# Altium schematic sheet CM001_V4_2.SchDoc(CM001_V4_2)
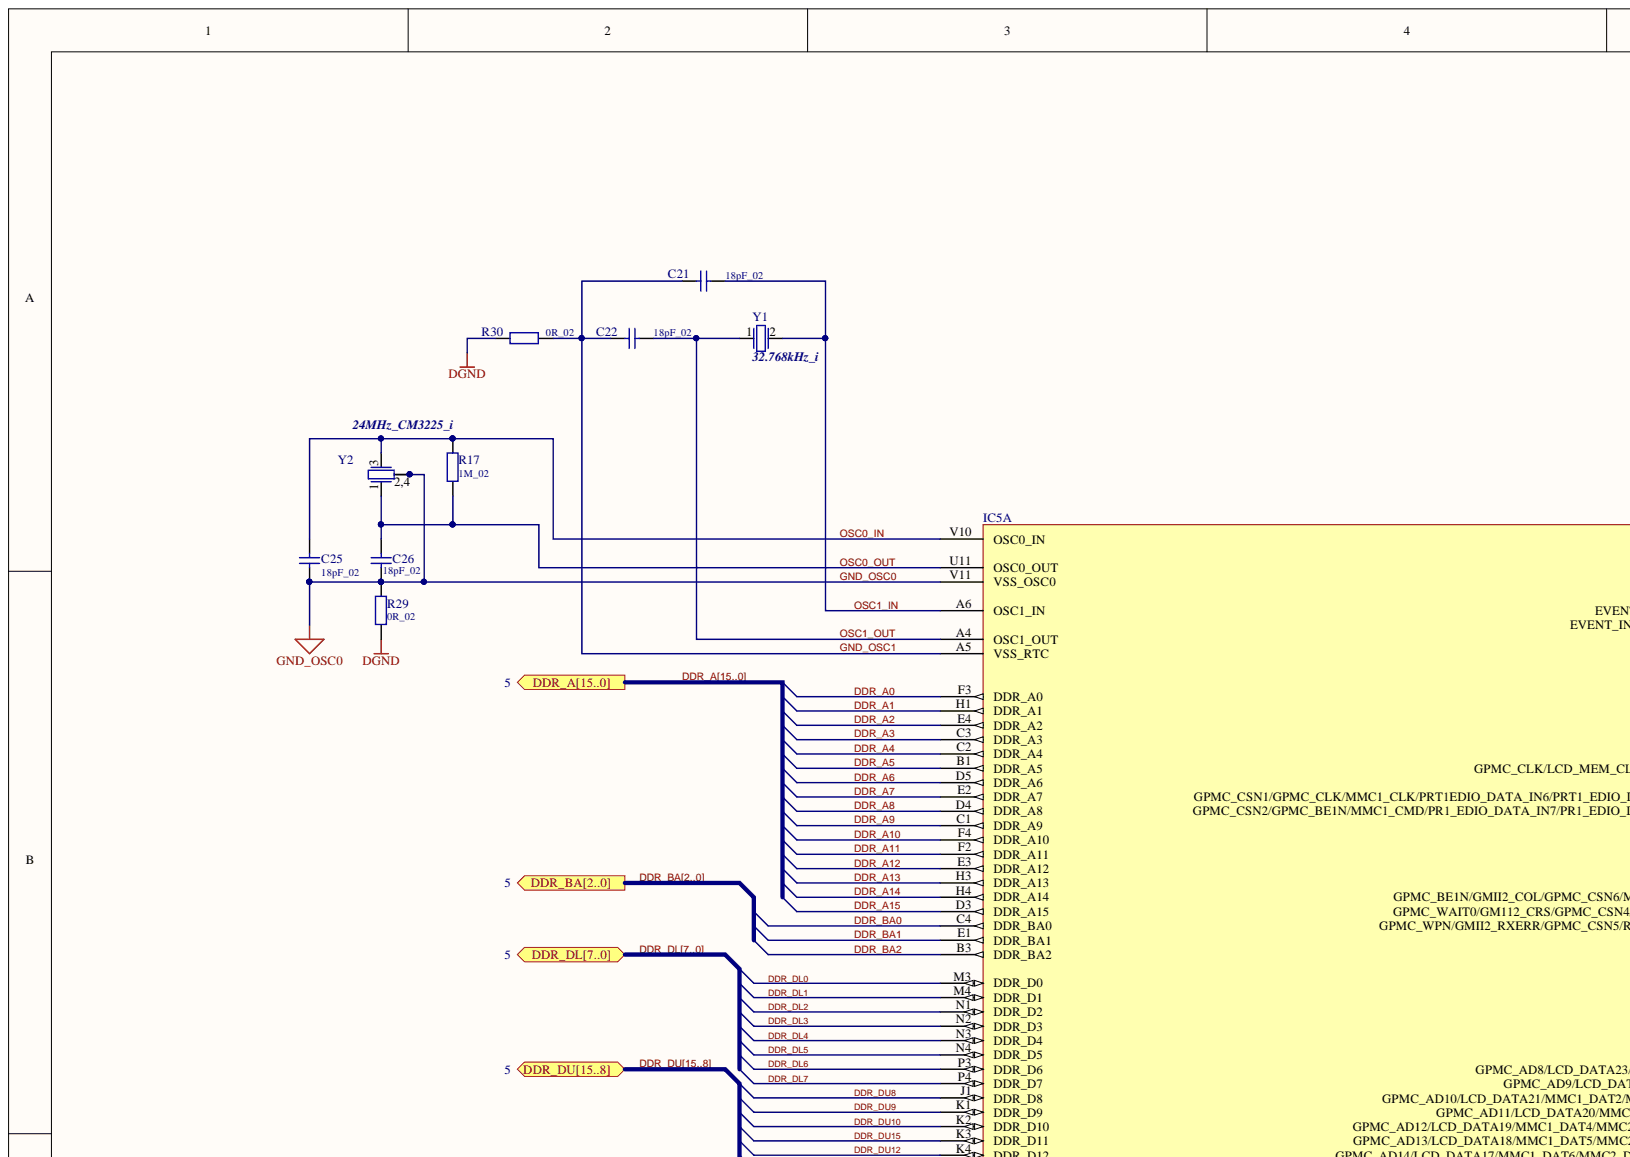
[diagram p0: CM001_V4_2.SchDoc, top left]
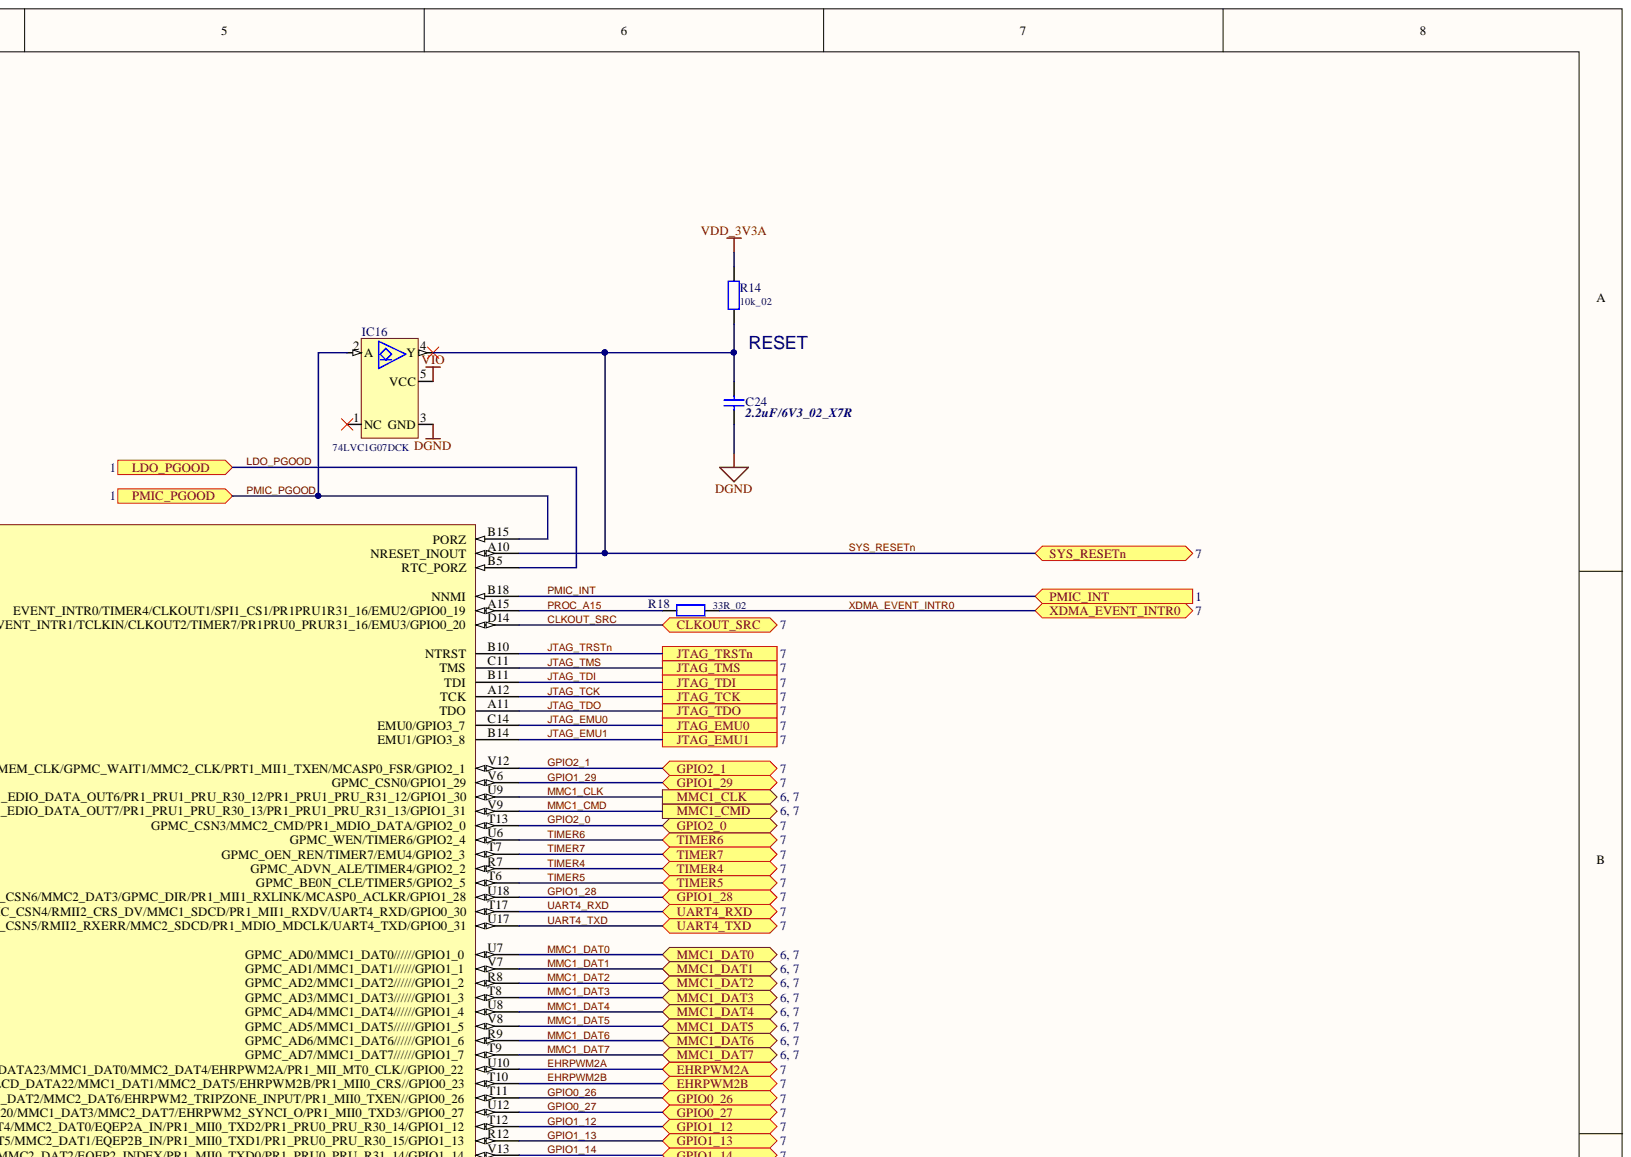
[diagram p1: CM001_V4_2.SchDoc, top right]
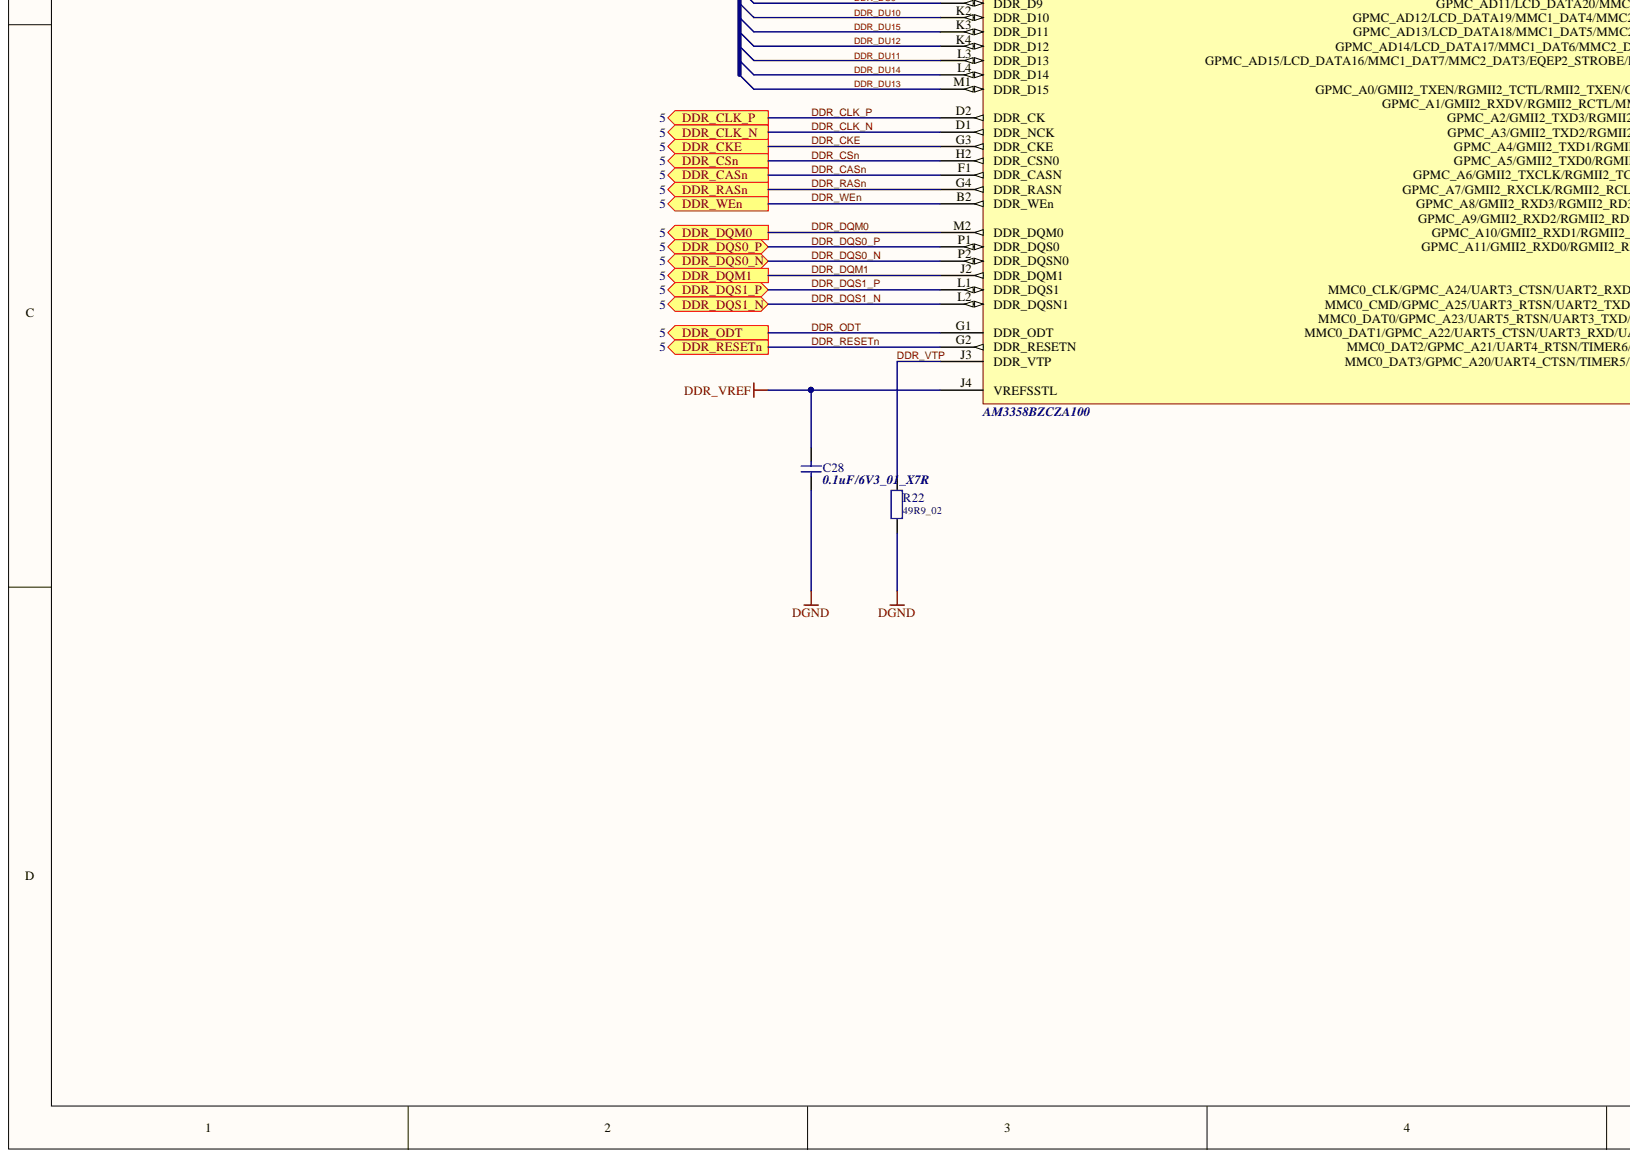
[diagram p2: CM001_V4_2.SchDoc, bottom left]
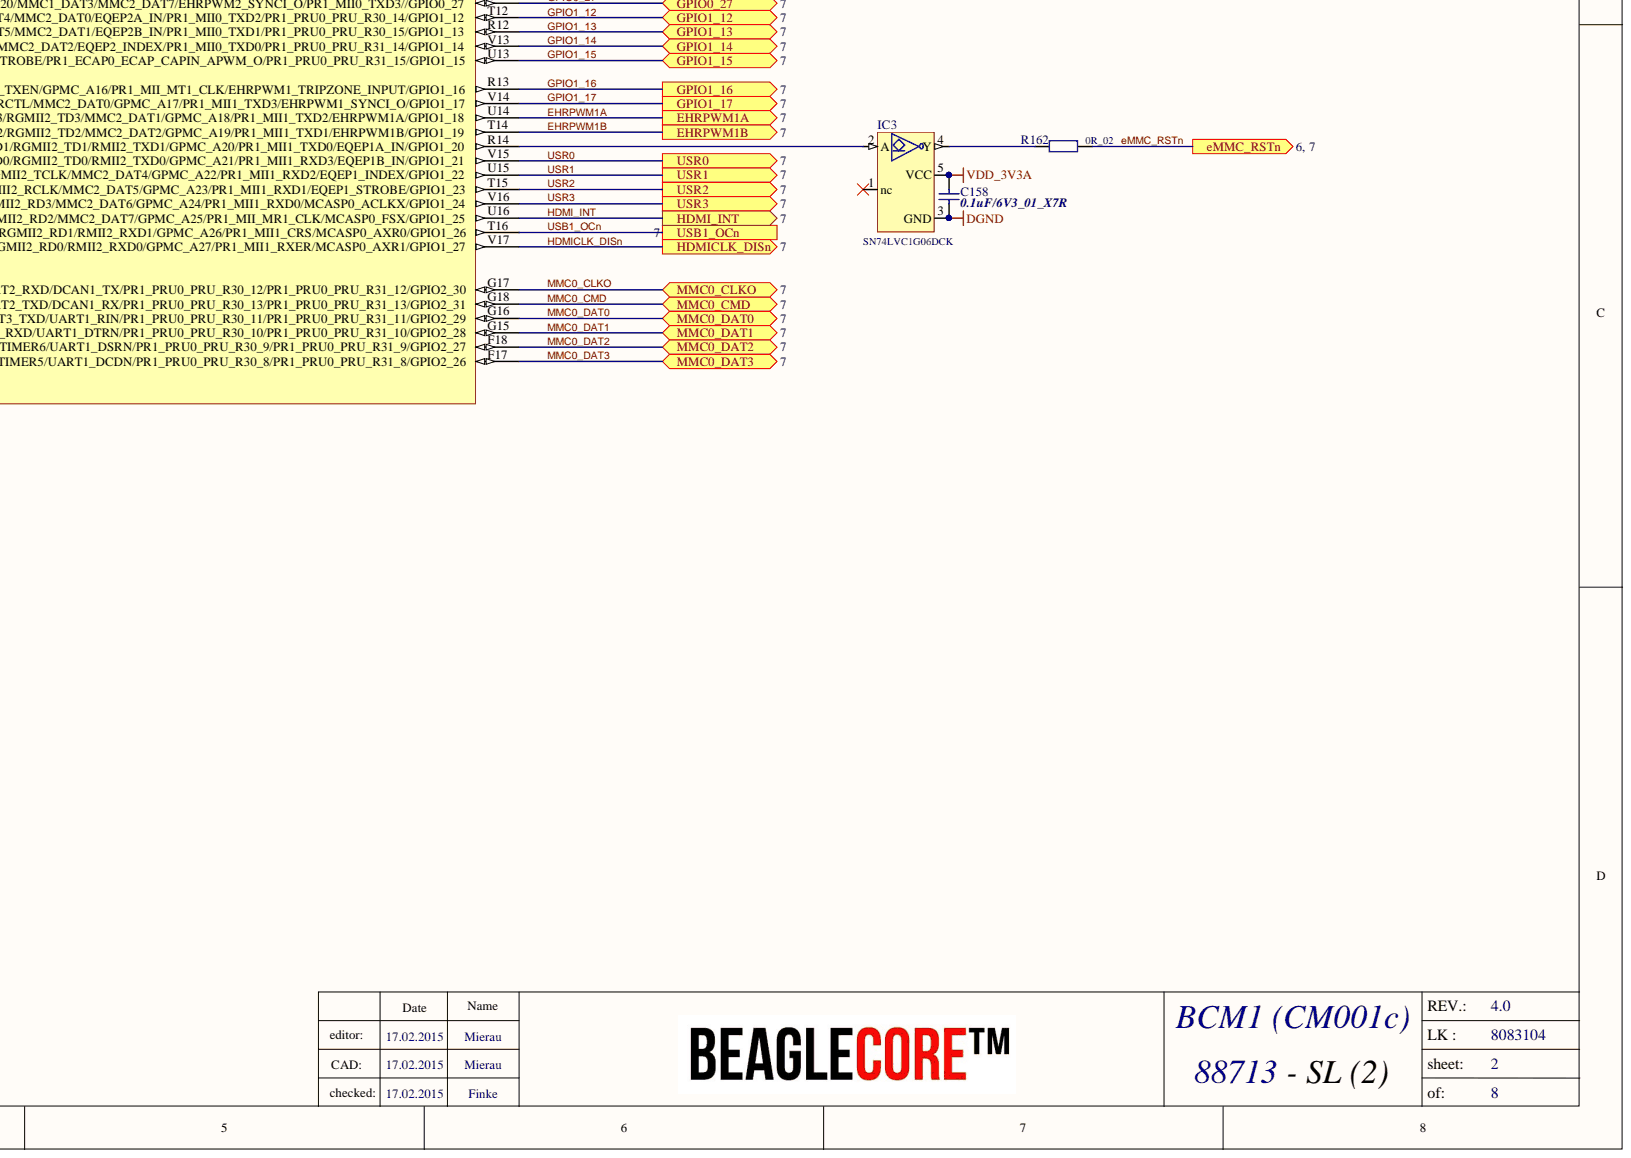
[diagram p3: CM001_V4_2.SchDoc, bottom right]

## Parts
C21  18pF_02  [CC1005-0402]
C22  18pF_02  [CC1005-0402]
C24  2.2uF/6V3_02_X7R  [CC1005-0402]
C24  2.2uF/6V3_02_X7R  [CC1005-0402]
C25  18pF_02  [CC1005-0402]
C26  18pF_02  [CC1005-0402]
C28  0.1uF/6V3_01_X7R  [CC0603-0201]
C28
C158  0.1uF/6V3_01_X7R  [CC0603-0201]
C158
IC3  SN74LVC1G06DCK  [SOT353]
IC5  AM3358BZCZ100  [BGA324_RM0.8_15x15]  (value not drawn)  (part A)
IC16  74LVC1G07DCK  [SOT353]
R14  10k_02  [CR1005-0402]
R17  1M_02  [CR1005-0402]
R18  33R_02  [CR1005-0402]
R22  49R9_02  [CR1005-0402]
R29  0R_02  [CR1005-0402]
R30  0R_02  [CR1005-0402]
R162  0R_02  [CR1005-0402]
Y1  32.768kHz_i  [CM3215]
Y1  32.768kHz_i  [CM3215]
Y2  24MHz_CM3225_i  [QUARZ CM3225]  (value not drawn)
Y2  24MHz_CM3225_i  [QUARZ CM3225]  (value not drawn)

## Ports
CLKOUT_SRC, DDR_A0, DDR_A1, DDR_A2, DDR_A3, DDR_A4, DDR_A5, DDR_A6, DDR_A7, DDR_A8, DDR_A9, DDR_A10, DDR_A11, DDR_A12, DDR_A13, DDR_A14, DDR_A15, DDR_A[15..0], DDR_BA0, DDR_BA1, DDR_BA2, DDR_BA[2..0], DDR_CASn, DDR_CKE, DDR_CLK_N, DDR_CLK_P, DDR_CSn, DDR_DL0, DDR_DL1, DDR_DL2, DDR_DL3, DDR_DL4, DDR_DL5, DDR_DL6, DDR_DL7, DDR_DL[7..0], DDR_DQM0, DDR_DQM1, DDR_DQS0_N, DDR_DQS0_P, DDR_DQS1_N, DDR_DQS1_P, DDR_DU8, DDR_DU9, DDR_DU10, DDR_DU11, DDR_DU12, DDR_DU13, DDR_DU14, DDR_DU15, DDR_DU[15..8], DDR_ODT, DDR_RASn, DDR_RESETn, DDR_WEn, EHRPWM1A, EHRPWM1B, EHRPWM2A, EHRPWM2B, eMMC_RSTn, GPIO0_26, GPIO0_27, GPIO1_12, GPIO1_13, GPIO1_14, GPIO1_15, GPIO1_16, GPIO1_17, GPIO1_28, GPIO1_29, GPIO2_0, GPIO2_1, HDMI_INT, HDMICLK_DISn, JTAG_EMU0, JTAG_EMU1, JTAG_TCK, JTAG_TDI, JTAG_TDO, JTAG_TMS, JTAG_TRSTn, LDO_PGOOD, MMC0_CLKO, MMC0_CMD, MMC0_DAT0, MMC0_DAT1, MMC0_DAT2, MMC0_DAT3, MMC1_CLK, MMC1_CMD, MMC1_DAT0, MMC1_DAT1, MMC1_DAT2, MMC1_DAT3, MMC1_DAT4, MMC1_DAT5, MMC1_DAT6, MMC1_DAT7, PMIC_INT, PMIC_PGOOD, SYS_RESETn, TIMER4, TIMER5, TIMER6, TIMER7, UART4_RXD, UART4_TXD, USB1_OCn, USR0, USR1, USR2, USR3, XDMA_EVENT_INTR0

## Nets
DDR_VREF: C28.2 IC5.J4(VREFSSTL)
DGND: C24.1 C28.1 C158.2 IC3.3(GND) IC16.3(GND) R22.1 R29.1 R30.1
VDD_3V3A: C158.1 IC3.5(VCC) R14.2
VIO: IC16.5(VCC)
CLKOUT_SRC: IC5.D14(EVENT_INTR1/TCLKIN/CLKOUT2/TIMER7/PR1PRU0_PRUR31_16/EMU3/GPIO0_20) ; port CLKOUT_SRC
DDR_A0: IC5.F3(DDR_A0) ; port DDR_A[15..0]
DDR_A1: IC5.H1(DDR_A1) ; port DDR_A[15..0]
DDR_A2: IC5.E4(DDR_A2) ; port DDR_A[15..0]
DDR_A3: IC5.C3(DDR_A3) ; port DDR_A[15..0]
DDR_A4: IC5.C2(DDR_A4) ; port DDR_A[15..0]
DDR_A5: IC5.B1(DDR_A5) ; port DDR_A[15..0]
DDR_A6: IC5.D5(DDR_A6) ; port DDR_A[15..0]
DDR_A7: IC5.E2(DDR_A7) ; port DDR_A[15..0]
DDR_A8: IC5.D4(DDR_A8) ; port DDR_A[15..0]
DDR_A9: IC5.C1(DDR_A9) ; port DDR_A[15..0]
DDR_A10: IC5.F4(DDR_A10) ; port DDR_A[15..0]
DDR_A11: IC5.F2(DDR_A11) ; port DDR_A[15..0]
DDR_A12: IC5.E3(DDR_A12) ; port DDR_A[15..0]
DDR_A13: IC5.H3(DDR_A13) ; port DDR_A[15..0]
DDR_A14: IC5.H4(DDR_A14) ; port DDR_A[15..0]
DDR_A15: IC5.D3(DDR_A15) ; port DDR_A[15..0]
DDR_BA0: IC5.C4(DDR_BA0) ; port DDR_BA[2..0]
DDR_BA1: IC5.E1(DDR_BA1) ; port DDR_BA[2..0]
DDR_BA2: IC5.B3(DDR_BA2) ; port DDR_BA[2..0]
DDR_CASn: IC5.F1(DDR_CASN) ; port DDR_CASn
DDR_CKE: IC5.G3(DDR_CKE) ; port DDR_CKE
DDR_CLK_N: IC5.D1(DDR_NCK) ; port DDR_CLK_N
DDR_CLK_P: IC5.D2(DDR_CK) ; port DDR_CLK_P
DDR_CSn: IC5.H2(DDR_CSN0) ; port DDR_CSn
DDR_DL0: IC5.M3(DDR_D0) ; port DDR_DL[7..0]
DDR_DL1: IC5.M4(DDR_D1) ; port DDR_DL[7..0]
DDR_DL2: IC5.N1(DDR_D2) ; port DDR_DL[7..0]
DDR_DL3: IC5.N2(DDR_D3) ; port DDR_DL[7..0]
DDR_DL4: IC5.N3(DDR_D4) ; port DDR_DL[7..0]
DDR_DL5: IC5.N4(DDR_D5) ; port DDR_DL[7..0]
DDR_DL6: IC5.P3(DDR_D6) ; port DDR_DL[7..0]
DDR_DL7: IC5.P4(DDR_D7) ; port DDR_DL[7..0]
DDR_DQM0: IC5.M2(DDR_DQM0) ; port DDR_DQM0
DDR_DQM1: IC5.J2(DDR_DQM1) ; port DDR_DQM1
DDR_DQS0_N: IC5.P2(DDR_DQSN0) ; port DDR_DQS0_N
DDR_DQS0_P: IC5.P1(DDR_DQS0) ; port DDR_DQS0_P
DDR_DQS1_N: IC5.L2(DDR_DQSN1) ; port DDR_DQS1_N
DDR_DQS1_P: IC5.L1(DDR_DQS1) ; port DDR_DQS1_P
DDR_DU8: IC5.J1(DDR_D8) ; port DDR_DU[15..8]
DDR_DU9: IC5.K1(DDR_D9) ; port DDR_DU[15..8]
DDR_DU10: IC5.K2(DDR_D10) ; port DDR_DU[15..8]
DDR_DU11: IC5.L3(DDR_D13) ; port DDR_DU[15..8]
DDR_DU12: IC5.K4(DDR_D12) ; port DDR_DU[15..8]
DDR_DU13: IC5.M1(DDR_D15) ; port DDR_DU[15..8]
DDR_DU14: IC5.L4(DDR_D14) ; port DDR_DU[15..8]
DDR_DU15: IC5.K3(DDR_D11) ; port DDR_DU[15..8]
DDR_ODT: IC5.G1(DDR_ODT) ; port DDR_ODT
DDR_RASn: IC5.G4(DDR_RASN) ; port DDR_RASn
DDR_RESETn: IC5.G2(DDR_RESETN) ; port DDR_RESETn
DDR_VTP: IC5.J3(DDR_VTP) R22.2
DDR_WEn: IC5.B2(DDR_WEn) ; port DDR_WEn
EHRPWM1A: IC5.U14(GPMC_A2/GMII2_TXD3/RGMII2_TD3/MMC2_DAT1/GPMC_A18/PR1_MII1_TXD2/EHRPWM1A/GPIO1_18) ; port EHRPWM1A
EHRPWM1B: IC5.T14(GPMC_A3/GMII2_TXD2/RGMII2_TD2/MMC2_DAT2/GPMC_A19/PR1_MII1_TXD1/EHRPWM1B/GPIO1_19) ; port EHRPWM1B
EHRPWM2A: IC5.U10(GPMC_AD8/LCD_DATA23/MMC1_DAT0/MMC2_DAT4/EHRPWM2A/PR1_MII_MT0_CLK//GPIO0_22) ; port EHRPWM2A
EHRPWM2B: IC5.T10(GPMC_AD9/LCD_DATA22/MMC1_DAT1/MMC2_DAT5/EHRPWM2B/PR1_MII0_CRS//GPIO0_23) ; port EHRPWM2B
eMMC_RSTn: R162.2 ; port eMMC_RSTn
GND_OSC0: C25.1 C26.1 IC5.V11(VSS_OSC0) R29.2 Y2.2 Y2.4
GND_OSC1: C21.1 C22.1 IC5.A5(VSS_RTC) R30.2
GPIO0_26: IC5.T11(GPMC_AD10/LCD_DATA21/MMC1_DAT2/MMC2_DAT6/EHRPWM2_TRIPZONE_INPUT/PR1_MII0_TXEN//GPIO0_26) ; port GPIO0_26
GPIO0_27: IC5.U12(GPMC_AD11/LCD_DATA20/MMC1_DAT3/MMC2_DAT7/EHRPWM2_SYNCI_O/PR1_MII0_TXD3//GPIO0_27) ; port GPIO0_27
GPIO1_12: IC5.T12(GPMC_AD12/LCD_DATA19/MMC1_DAT4/MMC2_DAT0/EQEP2A_IN/PR1_MII0_TXD2/PR1_PRU0_PRU_R30_14/GPIO1_12) ; port GPIO1_12
GPIO1_13: IC5.R12(GPMC_AD13/LCD_DATA18/MMC1_DAT5/MMC2_DAT1/EQEP2B_IN/PR1_MII0_TXD1/PR1_PRU0_PRU_R30_15/GPIO1_13) ; port GPIO1_13
GPIO1_14: IC5.V13(GPMC_AD14/LCD_DATA17/MMC1_DAT6/MMC2_DAT2/EQEP2_INDEX/PR1_MII0_TXD0/PR1_PRU0_PRU_R31_14/GPIO1_14) ; port GPIO1_14
GPIO1_15: IC5.U13(GPMC_AD15/LCD_DATA16/MMC1_DAT7/MMC2_DAT3/EQEP2_STROBE/PR1_ECAP0_ECAP_CAPIN_APWM_O/PR1_PRU0_PRU_R31_15/GPIO1_15) ; port GPIO1_15
GPIO1_16: IC5.R13(GPMC_A0/GMII2_TXEN/RGMII2_TCTL/RMII2_TXEN/GPMC_A16/PR1_MII_MT1_CLK/EHRPWM1_TRIPZONE_INPUT/GPIO1_16) ; port GPIO1_16
GPIO1_17: IC5.V14(GPMC_A1/GMII2_RXDV/RGMII2_RCTL/MMC2_DAT0/GPMC_A17/PR1_MII1_TXD3/EHRPWM1_SYNCI_O/GPIO1_17) ; port GPIO1_17
GPIO1_28: IC5.U18(GPMC_BE1N/GMII2_COL/GPMC_CSN6/MMC2_DAT3/GPMC_DIR/PR1_MII1_RXLINK/MCASP0_ACLKR/GPIO1_28) ; port GPIO1_28
GPIO1_29: IC5.V6(GPMC_CSN0/GPIO1_29) ; port GPIO1_29
GPIO2_0: IC5.T13(GPMC_CSN3/MMC2_CMD/PR1_MDIO_DATA/GPIO2_0) ; port GPIO2_0
GPIO2_1: IC5.V12(GPMC_CLK/LCD_MEM_CLK/GPMC_WAIT1/MMC2_CLK/PRT1_MII1_TXEN/MCASP0_FSR/GPIO2_1) ; port GPIO2_1
HDMI_INT: IC5.U16(GPMC_A9/GMII2_RXD2/RGMII2_RD2/MMC2_DAT7/GPMC_A25/PR1_MII_MR1_CLK/MCASP0_FSX/GPIO1_25) ; port HDMI_INT
HDMICLK_DISn: IC5.V17(GPMC_A11/GMII2_RXD0/RGMII2_RD0/RMII2_RXD0/GPMC_A27/PR1_MII1_RXER/MCASP0_AXR1/GPIO1_27) ; port HDMICLK_DISn
JTAG_EMU0: IC5.C14(EMU0/GPIO3_7) ; port JTAG_EMU0
JTAG_EMU1: IC5.B14(EMU1/GPIO3_8) ; port JTAG_EMU1
JTAG_TCK: IC5.A12(TCK) ; port JTAG_TCK
JTAG_TDI: IC5.B11(TDI) ; port JTAG_TDI
JTAG_TDO: IC5.A11(TDO) ; port JTAG_TDO
JTAG_TMS: IC5.C11(TMS) ; port JTAG_TMS
JTAG_TRSTn: IC5.B10(NTRST) ; port JTAG_TRSTn
LDO_PGOOD: IC5.B5(RTC_PORZ) ; port LDO_PGOOD
MMC0_CLKO: IC5.G17(MMC0_CLK/GPMC_A24/UART3_CTSN/UART2_RXD/DCAN1_TX/PR1_PRU0_PRU_R30_12/PR1_PRU0_PRU_R31_12/GPIO2_30) ; port MMC0_CLKO
MMC0_CMD: IC5.G18(MMC0_CMD/GPMC_A25/UART3_RTSN/UART2_TXD/DCAN1_RX/PR1_PRU0_PRU_R30_13/PR1_PRU0_PRU_R31_13/GPIO2_31) ; port MMC0_CMD
MMC0_DAT0: IC5.G16(MMC0_DAT0/GPMC_A23/UART5_RTSN/UART3_TXD/UART1_RIN/PR1_PRU0_PRU_R30_11/PR1_PRU0_PRU_R31_11/GPIO2_29) ; port MMC0_DAT0
MMC0_DAT1: IC5.G15(MMC0_DAT1/GPMC_A22/UART5_CTSN/UART3_RXD/UART1_DTRN/PR1_PRU0_PRU_R30_10/PR1_PRU0_PRU_R31_10/GPIO2_28) ; port MMC0_DAT1
MMC0_DAT2: IC5.F18(MMC0_DAT2/GPMC_A21/UART4_RTSN/TIMER6/UART1_DSRN/PR1_PRU0_PRU_R30_9/PR1_PRU0_PRU_R31_9/GPIO2_27) ; port MMC0_DAT2
MMC0_DAT3: IC5.F17(MMC0_DAT3/GPMC_A20/UART4_CTSN/TIMER5/UART1_DCDN/PR1_PRU0_PRU_R30_8/PR1_PRU0_PRU_R31_8/GPIO2_26) ; port MMC0_DAT3
MMC1_CLK: IC5.U9(GPMC_CSN1/GPMC_CLK/MMC1_CLK/PRT1EDIO_DATA_IN6/PRT1_EDIO_DATA_OUT6/PR1_PRU1_PRU_R30_12/PR1_PRU1_PRU_R31_12/GPIO1_30) ; port MMC1_CLK
MMC1_CMD: IC5.V9(GPMC_CSN2/GPMC_BE1N/MMC1_CMD/PR1_EDIO_DATA_IN7/PR1_EDIO_DATA_OUT7/PR1_PRU1_PRU_R30_13/PR1_PRU1_PRU_R31_13/GPIO1_31) ; port MMC1_CMD
MMC1_DAT0: IC5.U7(GPMC_AD0/MMC1_DAT0//////GPIO1_0) ; port MMC1_DAT0
MMC1_DAT1: IC5.V7(GPMC_AD1/MMC1_DAT1//////GPIO1_1) ; port MMC1_DAT1
MMC1_DAT2: IC5.R8(GPMC_AD2/MMC1_DAT2//////GPIO1_2) ; port MMC1_DAT2
MMC1_DAT3: IC5.T8(GPMC_AD3/MMC1_DAT3//////GPIO1_3) ; port MMC1_DAT3
MMC1_DAT4: IC5.U8(GPMC_AD4/MMC1_DAT4//////GPIO1_4) ; port MMC1_DAT4
MMC1_DAT5: IC5.V8(GPMC_AD5/MMC1_DAT5//////GPIO1_5) ; port MMC1_DAT5
MMC1_DAT6: IC5.R9(GPMC_AD6/MMC1_DAT6//////GPIO1_6) ; port MMC1_DAT6
MMC1_DAT7: IC5.T9(GPMC_AD7/MMC1_DAT7//////GPIO1_7) ; port MMC1_DAT7
OSC0_IN: C25.2 IC5.V10(OSC0_IN) R17.2 Y2.3
OSC0_OUT: C26.2 IC5.U11(OSC0_OUT) R17.1 Y2.1
OSC1_IN: C21.2 IC5.A6(OSC1_IN) Y1.2
OSC1_OUT: C22.2 IC5.A4(OSC1_OUT) Y1.1
PMIC_INT: IC5.B18(NNMI) ; port PMIC_INT
PMIC_PGOOD: IC5.B15(PORZ) IC16.2(A) ; port PMIC_PGOOD
PROC_A15: IC5.A15(EVENT_INTR0/TIMER4/CLKOUT1/SPI1_CS1/PR1PRU1R31_16/EMU2/GPIO0_19) R18.1
SYS_RESETn: C24.2 IC5.A10(NRESET_INOUT) IC16.4(Y) R14.1 ; port SYS_RESETn
TIMER4: IC5.R7(GPMC_ADVN_ALE/TIMER4/GPIO2_2) ; port TIMER4
TIMER5: IC5.T6(GPMC_BE0N_CLE/TIMER5/GPIO2_5) ; port TIMER5
TIMER6: IC5.U6(GPMC_WEN/TIMER6/GPIO2_4) ; port TIMER6
TIMER7: IC5.T7(GPMC_OEN_REN/TIMER7/EMU4/GPIO2_3) ; port TIMER7
UART4_RXD: IC5.T17(GPMC_WAIT0/GM112_CRS/GPMC_CSN4/RMII2_CRS_DV/MMC1_SDCD/PR1_MII1_RXDV/UART4_RXD/GPIO0_30) ; port UART4_RXD
UART4_TXD: IC5.U17(GPMC_WPN/GMII2_RXERR/GPMC_CSN5/RMII2_RXERR/MMC2_SDCD/PR1_MDIO_MDCLK/UART4_TXD/GPIO0_31) ; port UART4_TXD
USB1_OCn: IC5.T16(GPMC_A10/GMII2_RXD1/RGMII2_RD1/RMII2_RXD1/GPMC_A26/PR1_MII1_CRS/MCASP0_AXR0/GPIO1_26) ; port USB1_OCn
USR0: IC5.V15(GPMC_A5/GMII2_TXD0/RGMII2_TD0/RMII2_TXD0/GPMC_A21/PR1_MII1_RXD3/EQEP1B_IN/GPIO1_21) ; port USR0
USR1: IC5.U15(GPMC_A6/GMII2_TXCLK/RGMII2_TCLK/MMC2_DAT4/GPMC_A22/PR1_MII1_RXD2/EQEP1_INDEX/GPIO1_22) ; port USR1
USR2: IC5.T15(GPMC_A7/GMII2_RXCLK/RGMII2_RCLK/MMC2_DAT5/GPMC_A23/PR1_MII1_RXD1/EQEP1_STROBE/GPIO1_23) ; port USR2
USR3: IC5.V16(GPMC_A8/GMII2_RXD3/RGMII2_RD3/MMC2_DAT6/GPMC_A24/PR1_MII1_RXD0/MCASP0_ACLKX/GPIO1_24) ; port USR3
XDMA_EVENT_INTR0: R18.2 ; port XDMA_EVENT_INTR0
NetIC3_2: IC3.2(A) IC5.R14(GPMC_A4/GMII2_TXD1/RGMII2_TD1/RMII2_TXD1/GPMC_A20/PR1_MII1_TXD0/EQEP1A_IN/GPIO1_20)
NetIC3_4: IC3.4(Y) R162.1
N00145:
N00146:
N00147:
N00148:
N00149:
N00150:
N00151:
N00152:
N00153:
N00154:
N00155:

Not connected: IC3 1(nc); IC16 1(NC)

## Library data of the parts
C21 C22 C25 C26 = C18pF_02: Capacitor 0402 50V NPO; S/T: SMD; erstellt von: mie; Art-Nr.: 08.0002.0037; Value: 18pF_02; Simulation: CAP; Signal Integrity: Cap; Component Kind: Standard; Library Name: C_ies_Integrated_Library.IntLib
C24 = C2.2uF/6V3_02_X7R: Capacitor 0402 6V3 X7R; S/T: SMD; Art-Nr.: 08.0002.; erstellt von: Fin; Value: 2.2uF/6V3_02_X7R; Simulation: CAP; Signal Integrity: Cap; Revision: July-2002: Re-released for DXP Platform.; Hersteller: *; Component Kind: Standard; Bestellbezeichnung: *; Library Name: C_ies_Integrated_Library.IntLib
C24 = C2.2uF/6V3_02_X7R: Capacitor 0402 6V3 X7R; S/T: SMD; erstellt von: Fin; Art-Nr.: 08.0002.; Value: 2.2uF/6V3_02_X7R; Simulation: CAP; Signal Integrity: Cap; Revision: July-2002: Re-released for DXP Platform.; Hersteller: *; Component Kind: Standard; Bestellbezeichnung: *; Library Name: C_ies_Integrated_Library.IntLib
C28 C158 = C0.1uF/6V3_01_X7R: Capacitor 0201 6,3V X7R; S/T: SMD; Hersteller: any; erstellt von: Finke; Art-Nr.: 08.0002.0___; Produktbeispiel: Gudeco: muRata: GRM033R70J103JA01D; Value: 0.1uF/6V3_01_X7R; Supplier Part Number 2: 8USl_ZajxFVxkJsfRx-2TMMxBpVDD3GHQuOpPGq7oYPTEIlO4Ffw3x4_A6bjf4Mm; Supplier Part Number 1: 490-3167-6-ND; Supplier 2: Arrow; Supplier 1: Digi-Key; Component Kind: Standard; Bestellbezeichnung: *; Library Name: C_ies_Integrated_Library.IntLib
IC3 = IC74LVC1G06DCK: Single buffer/line driver, 5V-tolerant Input, Open collector, SOT353(SC70); S/T: SMD; Lieferant: Arrow; Hersteller: TI; Art-Nr.: 04.0501.00__; Value: SN74LVC1G06DCK; ComponentLink1Description: Datenblatt; Component Kind: Standard; Bestellbezeichnung: SN74LVC1G06DCK; Library Name: IC_ies_Integrated_Library.IntLib; ComponentLink1URL: u:\IC_Integrierte Bauteile\ti\sn74lvc1g06.pdf
IC5 = ICAM3358BZCZ100: Cortex A8 Processor 15x15; Hersteller: Texas Instruments; Art-Nr.: 04.0701.; Value: AM3358BZCZ100; ComponentLink1URL: http://www.ti.com/lit/ds/symlink/am3354.pdf; ComponentLink1Description: Link TI; Component Kind: Standard; Bestellbezeichnung: AM3358BZCZ100; Library Name: IC_ies_Integrated_Library.IntLib
IC16 = IC74LVC1G07DCK: Single Buffer/Driver With Open-Drain Output SOT353 (SC70); S/T: SMD; Lieferant: Arrow; Hersteller: TI; erstellt von: MIE; Art-Nr.: 04.0501.0057; Value: 74LVC1G07DCK; ComponentLink1Description: Datenblatt; Component Kind: Standard; Bestellbezeichnung: 74LVC1G07DCK; Library Name: IC_ies_Integrated_Library.IntLib; ComponentLink1URL: U:\IC_Integrierte Bauteile\ti\sn74lvc1g07.pdf
R14 = R10k_02: Resistor; 0402; 1%; S/T: SMD; Art-Nr.: 09.0002.0004; Value: 10k_02; Supplier Part Number 2: Digi-Key - 311-10.0KLRCT-ND; Supplier Part Number 1: 311-10.0KLRCT-ND; Supplier 2: Octopart; Supplier 1: Digi-Key; Component Kind: Standard; Library Name: R_ies_Integrated_Library.IntLib
R17 = R1M_02: Resistor; 0402; 1%; S/T: SMD; Art-Nr.: 09.0002.0015; Value: 1M_02; Component Kind: Standard; Library Name: R_ies_Integrated_Library.IntLib
R18 = R33R_02: Resistor; 0402; 1%; S/T: SMD; Art-Nr.: 09.0002.0014; Value: 33R_02; Component Kind: Standard; Library Name: R_ies_Integrated_Library.IntLib
R22 = R49R9_02: Resistor; 0402; 1%; S/T: SMD; Art-Nr.: 09.0002.0016; Value: 49R9_02; Component Kind: Standard; Library Name: R_ies_Integrated_Library.IntLib
R29 R30 R162 = R0R_02: Resistor; 0402; 5%; S/T: SMD; Art-Nr.: 09.0002.0002; Value: 0R_02; Supplier Part Number 2: Digi-Key - 311-0.0JRCT-ND; Supplier Part Number 1: 311-0.0JRCT-ND; Supplier 2: Octopart; Supplier 1: Digi-Key; Component Kind: Standard; Library Name: R_ies_Integrated_Library.IntLib
Y1 Y1 = Q32.768kHz_i: Quarz; 3,2x1,5mm,  -40°C bis 85°C; S/T: SMD; Hersteller: Epson; Bestellbezeichnung: FC-135 32.7680KA-A3; Art-Nr.: 12.0601.00__; Value: 32.768kHz_i; ComponentLink1URL: \\SERVER04\IES - Technische Datenbank\02) Fremdprodukte - Datenblätter, Handbücher, technische Informationen\angedachte Bauteile\Q_Quarz\Epson\FC-135R_en.pdf; ComponentLink1Description: Datenblatt; Component Kind: Standard; Library Name: Q_ies_Integrated_Library.IntLib
  Y1: Supplier Part Number 2: Digi-Key - 1253-1112-1-ND; Supplier Part Number 1: 1253-1112-1-ND; Supplier 2: Octopart; Supplier 1: Digi-Key
Y2 = Q24MHz_CM3225_i: Quarz; 3,2x2,5mm, 20pF, -40°C bis 85°C; S/T: SMD; Lieferant: Digikey; Hersteller1: Comtec Crystals; Hersteller: Fox Electronics; Bestellbezeichnung1: A024000000CIFBPN43DB; Bestellbezeichnung: FQ3225BR-24.000; Art-Nr.: 12.0601.0029; Hinweis: Alternative darf nicht unter dieser Artikelnummer geführt werden, da bis 105°; Value: 24MHz_CM3225_i; Supplier Part Number 2: Digi-Key - 1253-1745-1-ND; Supplier Part Number 1: 1253-1745-6-ND; Supplier 2: Octopart; Supplier 1: Digi-Key; Library Name: Q_ies_Integrated_Library.IntLib; ComponentLink1URL: \\SERVER04\IES - Technische Datenbank\02) Fremdprodukte - Datenblätter, Handbücher, technische Informationen\angedachte Bauteile\Q_Quarz\Fox\fq3225b_Series.pdf; ComponentLink1Description: Datenblatt; Component Kind: Standard; ComponentLink2Description: Datenblatt; ComponentLink2URL: u:\Q_Quarz\Comtec Crystals\ACI_CM3225.pdf
Y2 = Q24MHz_CM3225_i: Quarz; 3,2x2,5mm, 20pF, -40°C bis 85°C; S/T: SMD; Lieferant: Digikey; Hinweis: Alternative darf nicht unter dieser Artikelnummer geführt werden, da bis 105°; Hersteller: Fox Electronics; Bestellbezeichnung: FQ3225BR-24.000; Art-Nr.: 12.0601.0029; Bestellbezeichnung1: A024000000CIFBPN43DB; Hersteller1: Comtec Crystals; Value: 24MHz_CM3225_i; Library Name: Q_ies_Integrated_Library.IntLib; ComponentLink3Description: Datenblatt; ComponentLink2Description: Datenblatt; ComponentLink1Url: \\SERVER04\IES - Technische Datenbank\02) Fremdprodukte - Datenblätter, Handbücher, technische Informationen\angedachte Bauteile\Q_Quarz\Fox\fq3225b_Series.pdf; ComponentLink1Description: Datenblatt; Component Kind: Standard; ComponentLink3URL: C:\Users\kru\Downloads\A024000000CIFBPN43DB.pdf; ComponentLink2URL: u:\Q_Quarz\Comtec Crystals\ACI_CM3225.pdf
